# Altium schematic sheet ESP32S3_SP_V2.SchDoc(ESP32S3_SP_V2)
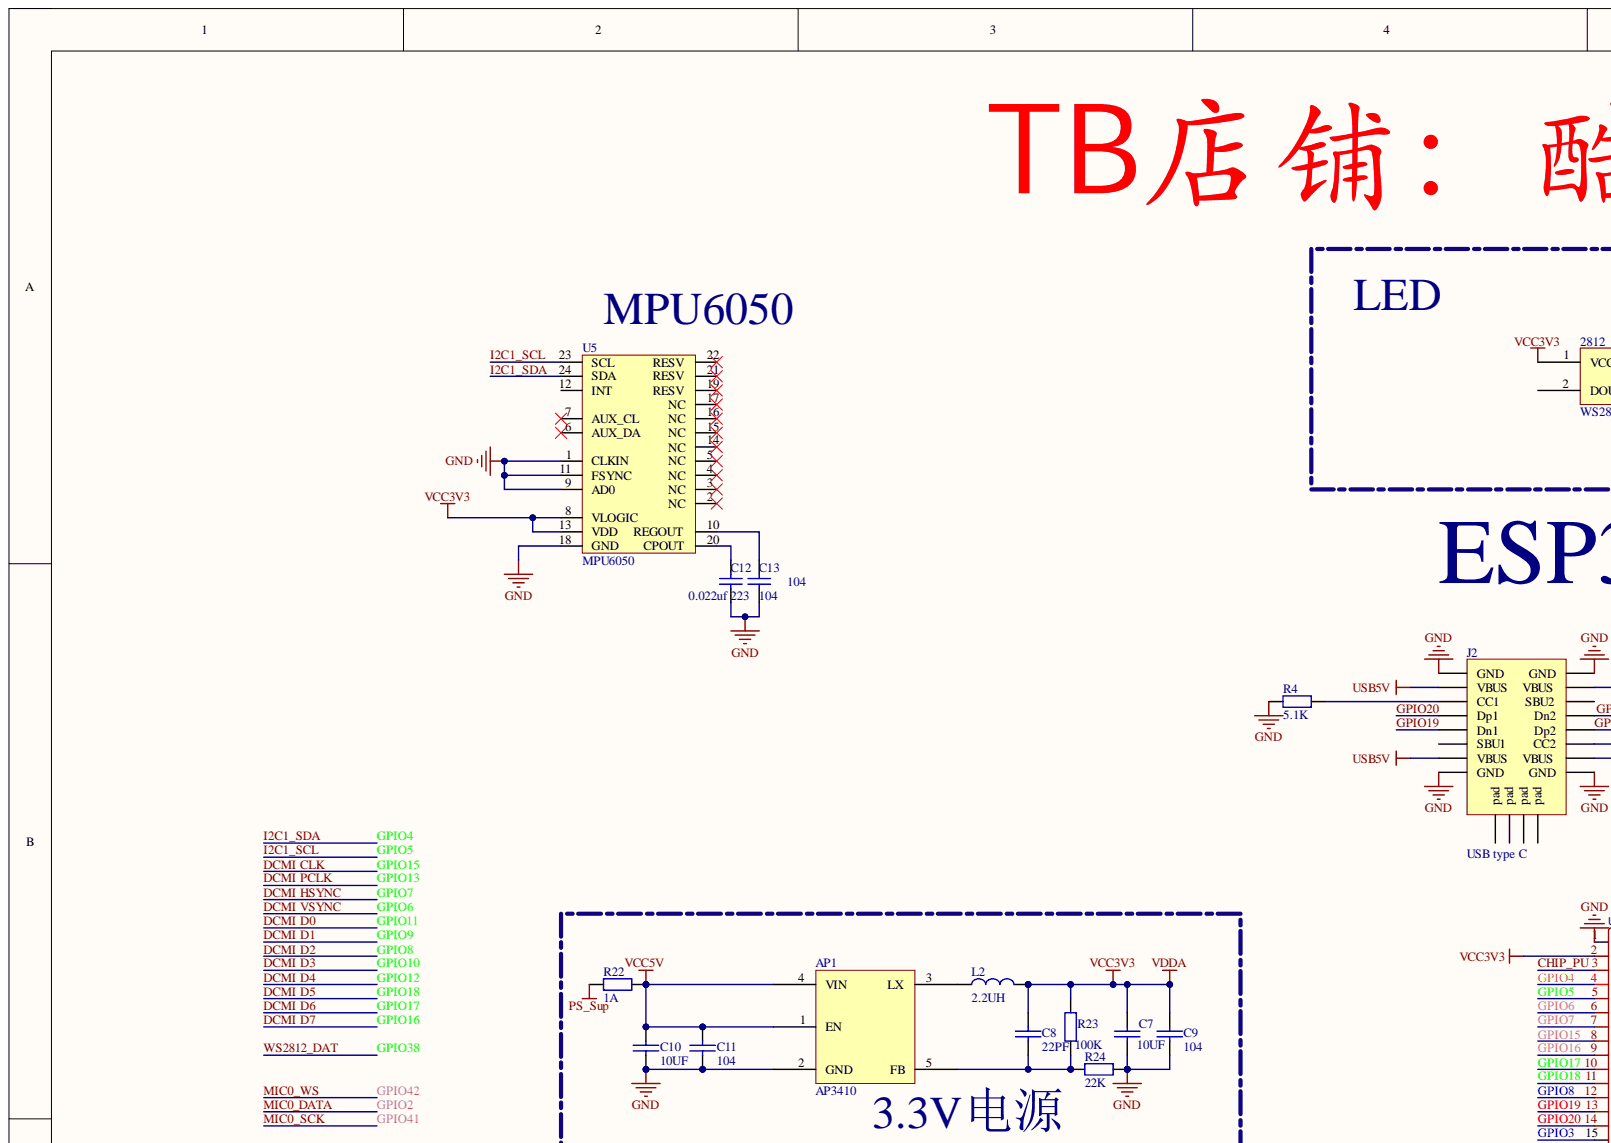
[diagram p0: ESP32S3_SP_V2.SchDoc, top left]
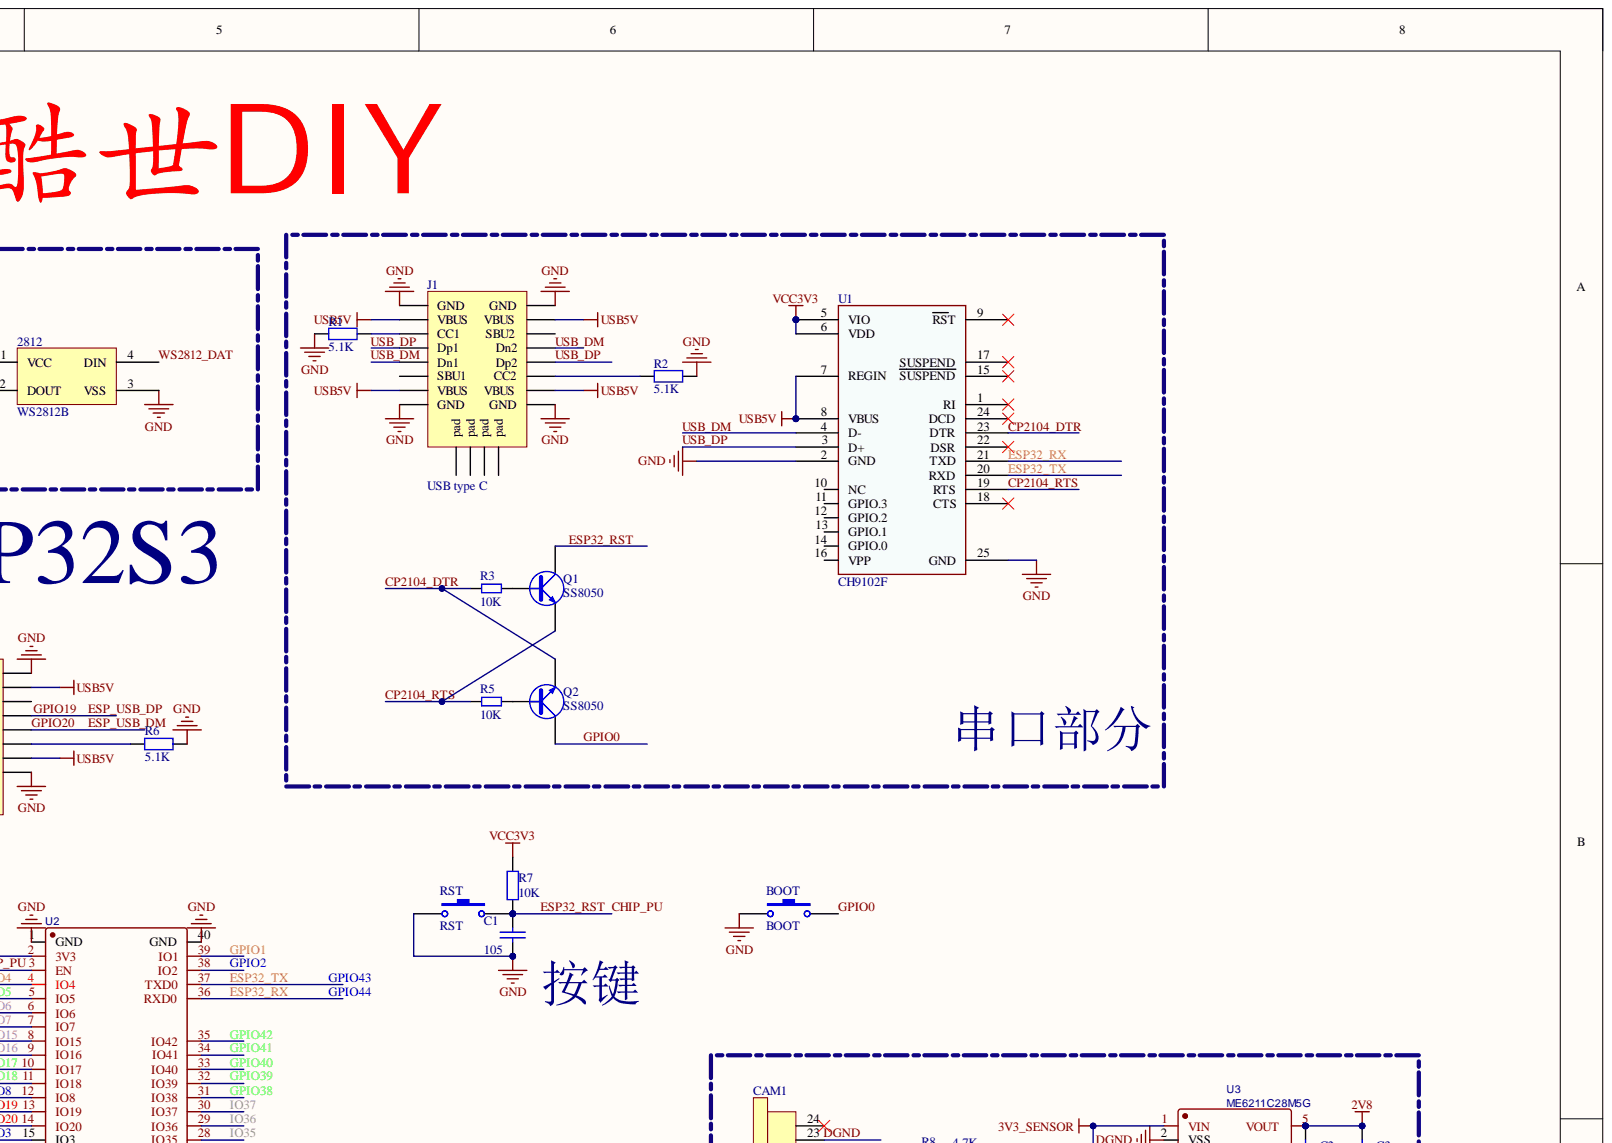
[diagram p1: ESP32S3_SP_V2.SchDoc, top right]
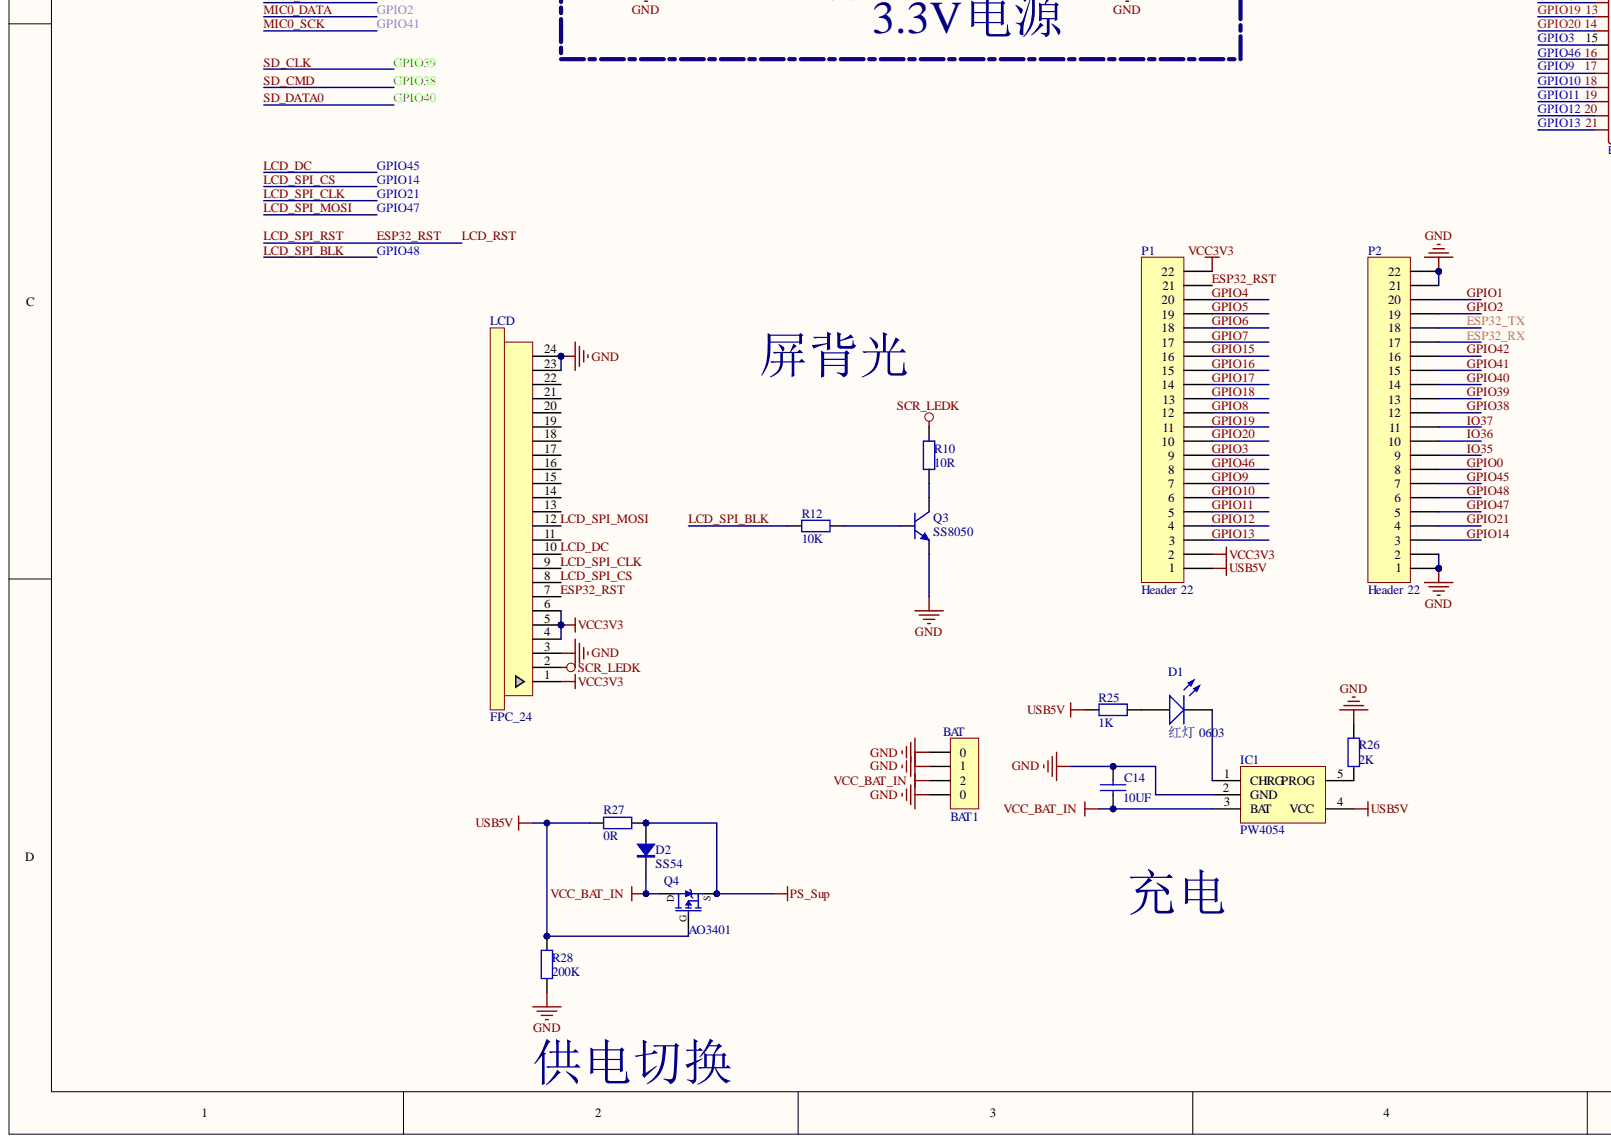
[diagram p2: ESP32S3_SP_V2.SchDoc, bottom left]
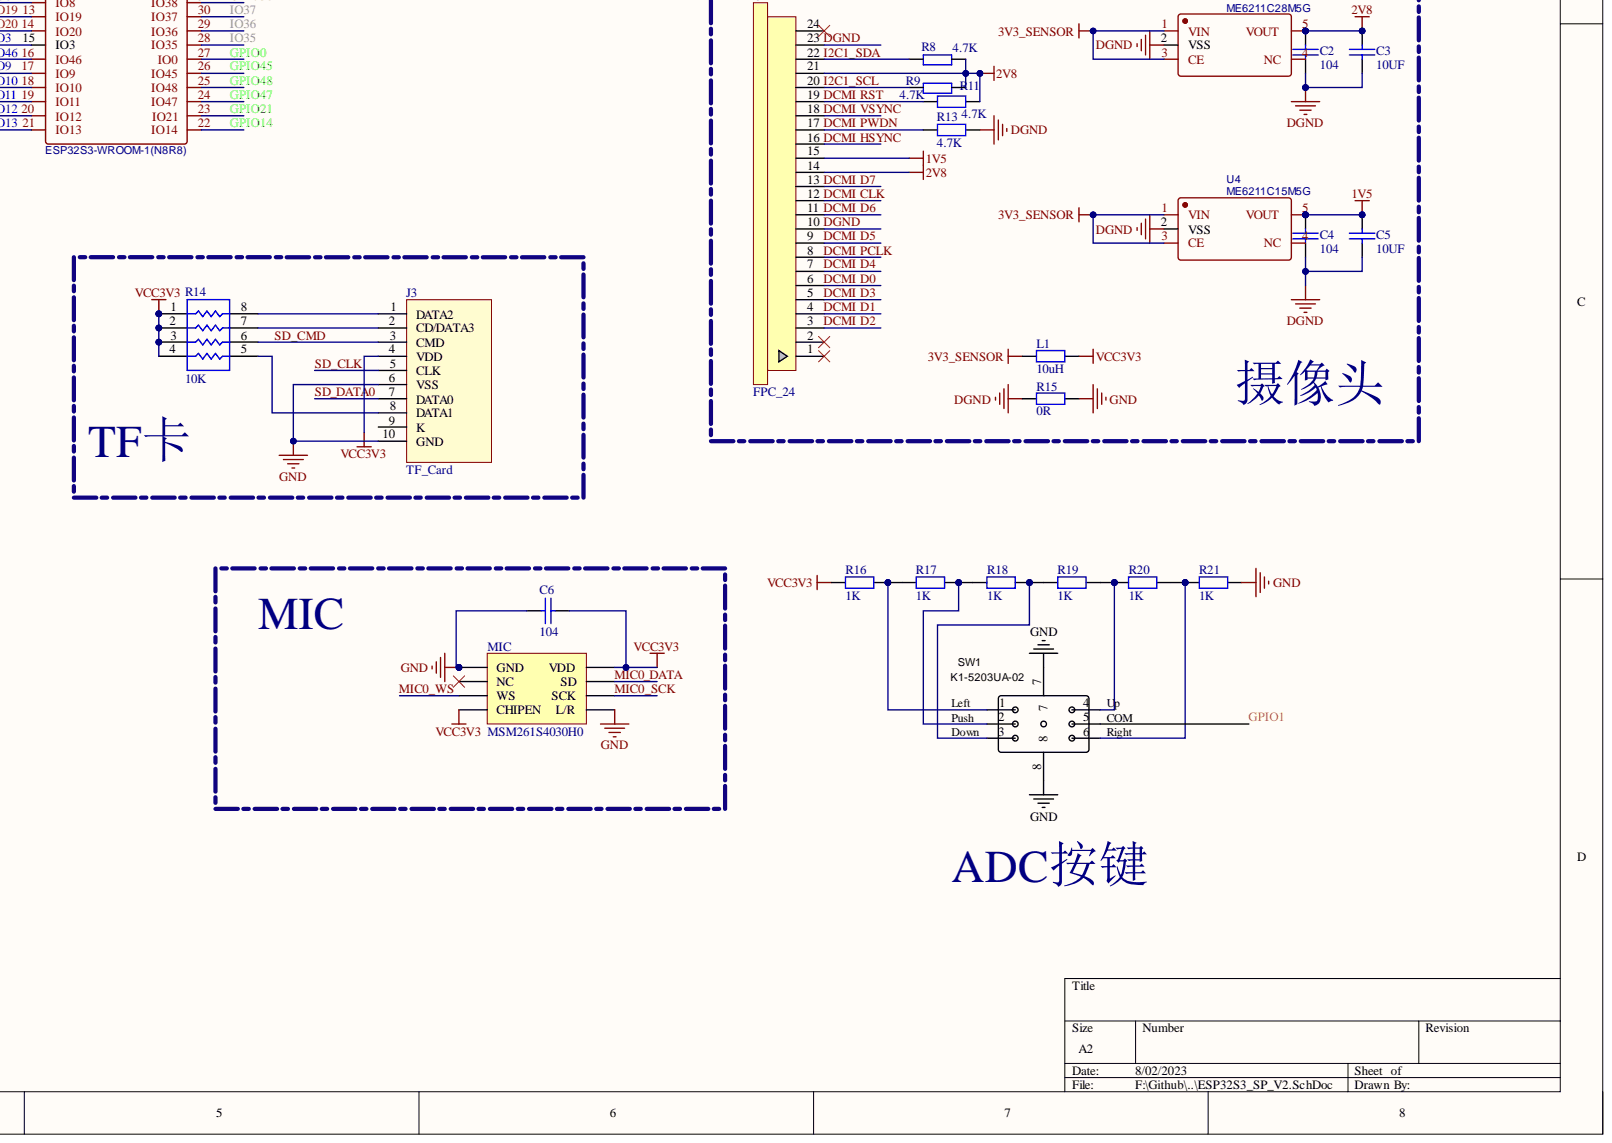
[diagram p3: ESP32S3_SP_V2.SchDoc, bottom right]

## Parts
2812  WS2812B  [LED_3528_RGB]
AP1  AP3410  [SOT23-5N]
BAT1  BAT  [MOLEX 53398-0271]
BOOT  BOOT  [KEY_1]
C1  105  [LC-0603_C]
C2  104  [LC-0603_C]
C3  10UF  [LC-0603_C]
C4  104  [LC-0603_C]
C5  10UF  [LC-0603_C]
C6  104  [LC-0603_C]
C7  10UF  [LC-0603_C]
C8  22PF  [LC-0603_C]
C9  104  [LC-0603_C]
C10  10UF  [LC-0603_C]
C11  104  [LC-0603_C]
C12  0.022uf  value 223  [LC-0603_C]
C13  104  [LC-0603_C]
C14  10UF  [LC-0603_C]
CAM1  FPC_24  [FPC_24]
D1  红灯 0603  Package: 0603  [0603_LED_S1]
D2  SS54  [SMA]
IC1  PW4054  [SOT23-5N]
J1  USB type C  [USB Type-C-16Pin_A]
J2  USB type C  [USB Type-C-16Pin_A]
J3  TF_Card  [TF卡座]
L1  10uH  [LC-0603_L]
L2  2.2UH  [CD32]
LCD  FPC_24  [ST7789V3_24Pin]
MIC  MSM261S4030H0  [MSM261S4030H0]
P1  Header 22  [HDR1X22]
P2  Header 22  [HDR1X22]
Q1  SS8050  [SOT23-3N]
Q2  SS8050  [SOT23-3N]
Q3  SS8050  [SOT23-3N]
Q4  AO3401  [SOT23-3N]
R1  5.1K  [LC-0603_R]
R2  5.1K  [LC-0603_R]
R3  10K  [LC-0603_R]
R4  5.1K  [LC-0603_R]
R5  10K  [LC-0603_R]
R6  5.1K  [LC-0603_R]
R7  10K  [LC-0603_R]
R8  4.7K  [LC-0603_R]
R9  4.7K  [LC-0603_R]
R10  10R  [LC-0603_R]
R11  4.7K  [LC-0603_R]
R12  10K  [LC-0603_R]
R13  4.7K  [LC-0603_R]
R14  10K  [RCA-8P4R-0603]
R15  0R  [LC-0603_R]
R16  1K  [LC-0603_R]
R17  1K  [LC-0603_R]
R18  1K  [LC-0603_R]
R19  1K  [LC-0603_R]
R20  1K  [LC-0603_R]
R21  1K  [LC-0603_R]
R22  1A  [0805_R]
R23  100K  [LC-0603_R]
R24  22K  [LC-0603_R]
R25  1K  [LC-0603_R]
R26  2K  [LC-0603_R]
R27  0R  [LC-0603_R]
R28  200K  [LC-0603_R]
RST  RST  [KEY_1]
SW1  K1-5203UA-02  [K1-5]
U1  CH9102F  [QFN24 4x4_N]
U2  ESP32S3-WROOM-1(N8R8)  [ESP32S3-WROOM-1]
U3  ME6211C28M5G  [SOT23-5N]
U4  ME6211C15M5G  [SOT23-5N]
U5  MPU6050  [QFN24 4x4_N]

## Nets
1V5: C4.1 C5.1 CAM1.15 U4.5(VOUT)
2V8: C2.1 C3.1 CAM1.14 CAM1.21 R8.2 R9.2 R11.2 U3.5(VOUT)
3V3_SENSOR: L1.2 U3.1(VIN) U3.3(CE) U4.1(VIN) U4.3(CE)
GND: 2812.3(VSS) AP1.2(GND) BAT1.0 BAT1.1 BOOT.1 C1.1 C6.1 C7.1 C9.1 C10.1 C11.1 C12.1 C13.1 C14.2 IC1.2(GND) J1.A1(GND) J1.A12(GND) J1.B1(GND) J1.B12(GND) J2.A1(GND) J2.A12(GND) J2.B1(GND) J2.B12(GND) J3.6(VSS) J3.10(GND) LCD.3 LCD.23 LCD.24 MIC.1(GND) MIC.5(L/R) P2.1 P2.2 P2.21 P2.22 Q3.2 R1.1 R2.1 R4.1 R6.2 R15.1 R21.2 R24.1 R26.2 R28.1 RST.1 SW1.7 SW1.8 U1.2(GND) U1.25(GND) U2.1(GND) U2.40(GND) U5.1(CLKIN) U5.9(AD0) U5.11(FSYNC) U5.18(GND)
PS_Sup: D2.1 Q4.2 R22.2 R27.1
SCR_LEDK: LCD.2 R10.1
USB5V: IC1.4(VCC) J1.A4(VBUS) J1.A9(VBUS) J1.B4(VBUS) J1.B9(VBUS) J2.A4(VBUS) J2.A9(VBUS) J2.B4(VBUS) J2.B9(VBUS) P1.1 Q4.1 R25.2 R27.2 R28.2 U1.7(REGIN) U1.8(VBUS)
VCC3V3: 2812.1(VCC) C6.2 C7.2 C8.2 C9.2 J3.4(VDD) L1.1 L2.1 LCD.1 LCD.4 LCD.5 LCD.6 MIC.4(CHIPEN) MIC.8(VDD) P1.2 P1.22 R7.1 R14.1 R14.2 R14.3 R14.4 R16.1 R23.1 U1.5(VIO) U1.6(VDD) U2.2(3V3) U5.8(VLOGIC) U5.13(VDD)
VCC5V: AP1.1(EN) AP1.4(VIN) C10.2 C11.2 R22.1
VCC_BAT_IN: BAT1.2 C14.1 D2.2 IC1.3(BAT) Q4.3
CHIP_PU: C1.2 LCD.7 P1.21 Q1.3 R7.2 RST.2 U2.3(EN)
COM: P2.20 SW1.5 U2.39(IO1)
CP2104_DTR: Q2.2 R3.1 U1.23(DTR)
CP2104_RTS: Q1.2 R5.1 U1.19(RTS)
DCMI CLK: CAM1.12 P1.16 U2.8(IO15)
DCMI D0: CAM1.6 P1.5 U2.19(IO11)
DCMI D1: CAM1.4 P1.7 U2.17(IO9)
DCMI D2: CAM1.3 P1.12 U2.12(IO8)
DCMI D3: CAM1.5 P1.6 U2.18(IO10)
DCMI D4: CAM1.7 P1.4 U2.20(IO12)
DCMI D5: CAM1.9 P1.13 U2.11(IO18)
DCMI D6: CAM1.11 P1.14 U2.10(IO17)
DCMI D7: CAM1.13 P1.15 U2.9(IO16)
DCMI HSYNC: CAM1.16 P1.17 U2.7(IO7)
DCMI PCLK: CAM1.8 P1.3 U2.21(IO13)
DCMI PWDN: CAM1.17 R13.1
DCMI RST: CAM1.19 R11.1
DCMI VSYNC: CAM1.18 P1.18 U2.6(IO6)
DGND: C2.2 C3.2 C4.2 C5.2 CAM1.10 CAM1.23 R13.2 R15.2 U3.2(VSS) U4.2(VSS)
Down: R18.2 R19.1 SW1.3
ESP32_RX: P2.17 U1.21(TXD) U2.36(RXD0)
ESP32_TX: P2.18 U1.20(RXD) U2.37(TXD0)
ESP_USB_DM: J2.A6(Dp1) J2.B6(Dp2) P1.10 U2.14(IO20)
ESP_USB_DP: J2.A7(Dn1) J2.B7(Dn2) P1.11 U2.13(IO19)
GPIO0: BOOT.2 P2.8 Q2.3 U2.27(IO0)
GPIO2: MIC.7(SD) P2.19 U2.38(IO2)
GPIO3: P1.9 U2.15(IO3)
GPIO4: CAM1.22 P1.20 R8.1 U2.4(IO4) U5.24(SDA)
GPIO5: CAM1.20 P1.19 R9.1 U2.5(IO5) U5.23(SCL)
GPIO14: LCD.8 P2.3 U2.22(IO14)
GPIO21: LCD.9 P2.4 U2.23(IO21)
GPIO38: 2812.4(DIN) J3.3(CMD) P2.12 R14.6 U2.31(IO38)
GPIO39: J3.5(CLK) P2.13 U2.32(IO39)
GPIO40: J3.7(DATA0) P2.14 U2.33(IO40)
GPIO41: MIC.6(SCK) P2.15 U2.34(IO41)
GPIO42: MIC.3(WS) P2.16 U2.35(IO42)
GPIO45: LCD.10 P2.7 U2.26(IO45)
GPIO46: P1.8 U2.16(IO46)
GPIO47: LCD.12 P2.5 U2.24(IO47)
GPIO48: P2.6 R12.1 U2.25(IO48)
IO35: P2.9 U2.28(IO35)
IO36: P2.10 U2.29(IO36)
IO37: P2.11 U2.30(IO37)
Left: R16.2 R17.1 SW1.1
Push: R17.2 R18.1 SW1.2
Right: R20.2 R21.1 SW1.6
Up: R19.2 R20.1 SW1.4
USB_DM: J1.A7(Dn1) J1.B7(Dn2) U1.4(D-)
USB_DP: J1.A6(Dp1) J1.B6(Dp2) U1.3(D+)
NetAP1_3: AP1.3(LX) L2.2
NetAP1_5: AP1.5(FB) C8.1 R23.2 R24.2
NetC12_2: C12.2 U5.20(CPOUT)
NetC13_2: C13.2 U5.10(REGOUT)
NetD1_A: D1.A R25.1
NetD1_K: D1.K IC1.1(CHRG)
NetIC1_5: IC1.5(PROG) R26.1
NetJ1_A5: J1.A5(CC1) R1.2
NetJ1_B5: J1.B5(CC2) R2.2
NetJ2_A5: J2.A5(CC1) R4.2
NetJ2_B5: J2.B5(CC2) R6.1
NetJ3_1: J3.1(DATA2) R14.8
NetJ3_2: J3.2(CD/DATA3) R14.7
NetJ3_8: J3.8(DATA1) R14.5
NetQ1_1: Q1.1 R3.2
NetQ2_1: Q2.1 R5.2
NetQ3_1: Q3.1 R12.2
NetQ3_3: Q3.3 R10.2

Not connected: 2812 2(DOUT); CAM1 1 2 24; J1 0(pad) A8(SBU1) B8(SBU2); J2 0(pad) A8(SBU1) B8(SBU2); J3 9(K); LCD 11 13 14 15 16 17 18 19 20 21 22; MIC 2(NC); U1 1(RI) 9(RST) 10(NC) 11(GPIO.3) 12(GPIO.2) 13(GPIO.1) 14(GPIO.0) 15(SUSPEND) 16(VPP) 17(SUSPEND) 18(CTS) 22(DSR) 24(DCD); U3 4(NC); U4 4(NC); U5 2(NC) 3(NC) 4(NC) 5(NC) 6(AUX_DA) 7(AUX_CL) 12(INT) 14(NC) 15(NC) 16(NC) 17(NC) 19(RESV) 21(RESV) 22(RESV)

## Library data of the parts
BAT1 = Header 4: molex 53261-0271 2P; BOM名称: 4P接插件; 版权归属: 源创客; Component Kind: Standard; Library Name: 3D Mode.IntLib; 学习资源: www.ooocke.com
BOOT RST = SW-PB: Switch; Component Kind: Standard; LatestRevisionDate: 17-Jul-2002; LatestRevisionNote: Re-released for DXP Platform.; PackageDescription: Switch; 2 Leads; PackageReference: SPST-2; Published: 24-Mar-1999; Library Name: 自创 (1).SchLib; Publisher: Altium Limited
C1 = C: Capacitor; Component Kind: Standard; Library Name: Tribrer_GENERAL.SchLib
C2-C6 = CAP: Component Kind: Standard; Library Name: XKZ.SchLib
C7-C11 C14 = cap: Component Kind: Standard; Library Name: 自创.SchLib
C12 C13 = Cap: Capacitor; Component Kind: Standard; LatestRevisionDate: 17-Jul-2002; LatestRevisionNote: Re-released for DXP Platform.; Library Name: Miscellaneous Devices.IntLib; PackageDescription: Radial Cap, Thru-Hole; 2 Leads; 0.3 in Pin Spacing; PackageReference: RAD-0.3; Published: 8-Jun-2000; Signal Integrity: Cap; Simulation: CAP; Publisher: Altium Limited
  C13: Value: 104
D1 = 0603 白灯: 发光二极管; Component Kind: Standard; ComponentLink1Description: 供应商链接; ComponentLink1URL: http://www.szlcsc.com/product/details_2663.html; Library Name: Miscellaneous Devices LC.IntLib; Supplier: LC; SuppliersPartNumber: C2290
D2 = SS14: 肖特基二极管; 属性: 40V/1A; 版权归属: 源创客; BOM名称: 肖特基二极管; Component Kind: Standard; Library Name: 8.1   - 二极管-整流桥.SchLib; 学习资源: www.ooocke.com
IC1 = LTC4054: 锂电池充电芯片; 版权归属: 源创客; BOM名称: 锂电池充电芯片; Component Kind: Standard; Library Name: 1.3   - 电源类芯片.SchLib; 学习资源: www.ooocke.com
J1 J2 = USB_C: Component Kind: Standard; Library Name: XKZ.SchLib
J3 = TF_Card: TF卡座; 版权归属: 源创客; BOM名称: TF卡座; Component Kind: Standard; Library Name: Source.IntLib; 学习资源: www.ooocke.com
L1 R1 R2 R4 R6 R7 R10-R13 R15-R28 = RES: 普通电阻; Component Kind: Standard; Library Name: LIB_MANGO.IntLib
L2 = L1: 电感; Component Kind: Standard; Library Name: XKZ.SchLib
P1 P2 = Header 22: Header, 22-Pin; Component Kind: Standard; LatestRevisionDate: 17-Jul-2002; LatestRevisionNote: Re-released for DXP Platform.; Library Name: Miscellaneous Connectors.IntLib; Signal Integrity: Connector; Publisher: Altium Limited
Q1 Q2 = Q S9013: Component Kind: Standard; Library Name: GENERAL.SchLib
Q3 = 8050-SMD: 高频放大-NPN型; 属性: 40V 1.5A 1W 100MHZ; 版权归属: 源创客; BOM名称: 高频放大-NPN型; Component Kind: Standard; Library Name: 3D库.IntLib; 学习资源: www.ooocke.com
Q4 = AO3401: P沟道 30V/4.2A; BOM名称: 场效应管; 版权归属: 源创客; Component Kind: Standard; Library Name: 6.1   - 场效应管-可控硅.SchLib; 学习资源: www.ooocke.com; 描述: P沟道 30V/4.2A
R3 R5 = R_0Ω_0603: Component Kind: Standard; Library Name: Rtnzero_HW_Lib.IntLib
R8 R9 = R_1: Component Kind: Standard; Library Name: Explorer STM32F407封装库.IntLib; value: 4.7K
R14 = RES4: Component Kind: Standard; Library Name: LONG_lclr.SchLib
SW1 = K1-5203UA-02: BOM_Manufacturer: HRO; Contributor: LCSC; BOM_Manufacturer Part: K1-5203UA-02; BOM_Supplier: LCSC; BOM_Supplier Part: C145898; Component Kind: Standard; spicePre: S; spiceSymbolName: K1-5203UA-02
U1 = CP2104: Component Kind: Standard; Library Name: LIB_MANGO.IntLib
U2 = ESP32S3-WROOM-1: BOM_Manufacturer: Espressif Systems; BOM_Manufacturer Part: ESP32-WROOM-32E(8MB); Contributor: LCSC; BOM_JLCPCB Part Class: Extended Part; BOM_Supplier: LCSC; BOM_Supplier Part: C701342; Component Kind: Standard; spicePre: U; spiceSymbolName: ESP32-WROOM-32E(8MB); Library Name: XKZ.SchLib
U3 = ME6211C28M5G: BOM_Manufacturer: MICRONE(南京微盟); BOM_Manufacturer Part: ME6211C28M5G-N; BOM_JLCPCB Part Class: Extended Part; BOM_Supplier: LCSC; BOM_Supplier Part: C53099; Component Kind: Standard; spicePre: U; spiceSymbolName: ME6211C28M5G
U4 = ME6211C15M5G: BOM_Manufacturer: MICRONE(南京微盟); BOM_Manufacturer Part: ME6211C15M5G-N; BOM_JLCPCB Part Class: Extended Part; BOM_Supplier: LCSC; BOM_Supplier Part: C53100; Component Kind: Standard; spicePre: U; spiceSymbolName: ME6211C15M5G
U5 = MPU6050: 9轴运动处理传感器; Component Kind: Standard; Library Name: Explorer STM32F407封装库.IntLib
2812 = WS2812B: Component Kind: Standard; Library Name: XKZ.SchLib
AP1 = AP3410: Component Kind: Standard; Library Name: XKZ.SchLib
CAM1 LCD = FPC_24: Component Kind: Standard; Library Name: XKZ.SchLib
MIC = MSM261S4030H0: Component Kind: Standard; Library Name: XKZ.SchLib
